# Altium schematic sheet 09 ELRS3.0 V1.1.SchDoc
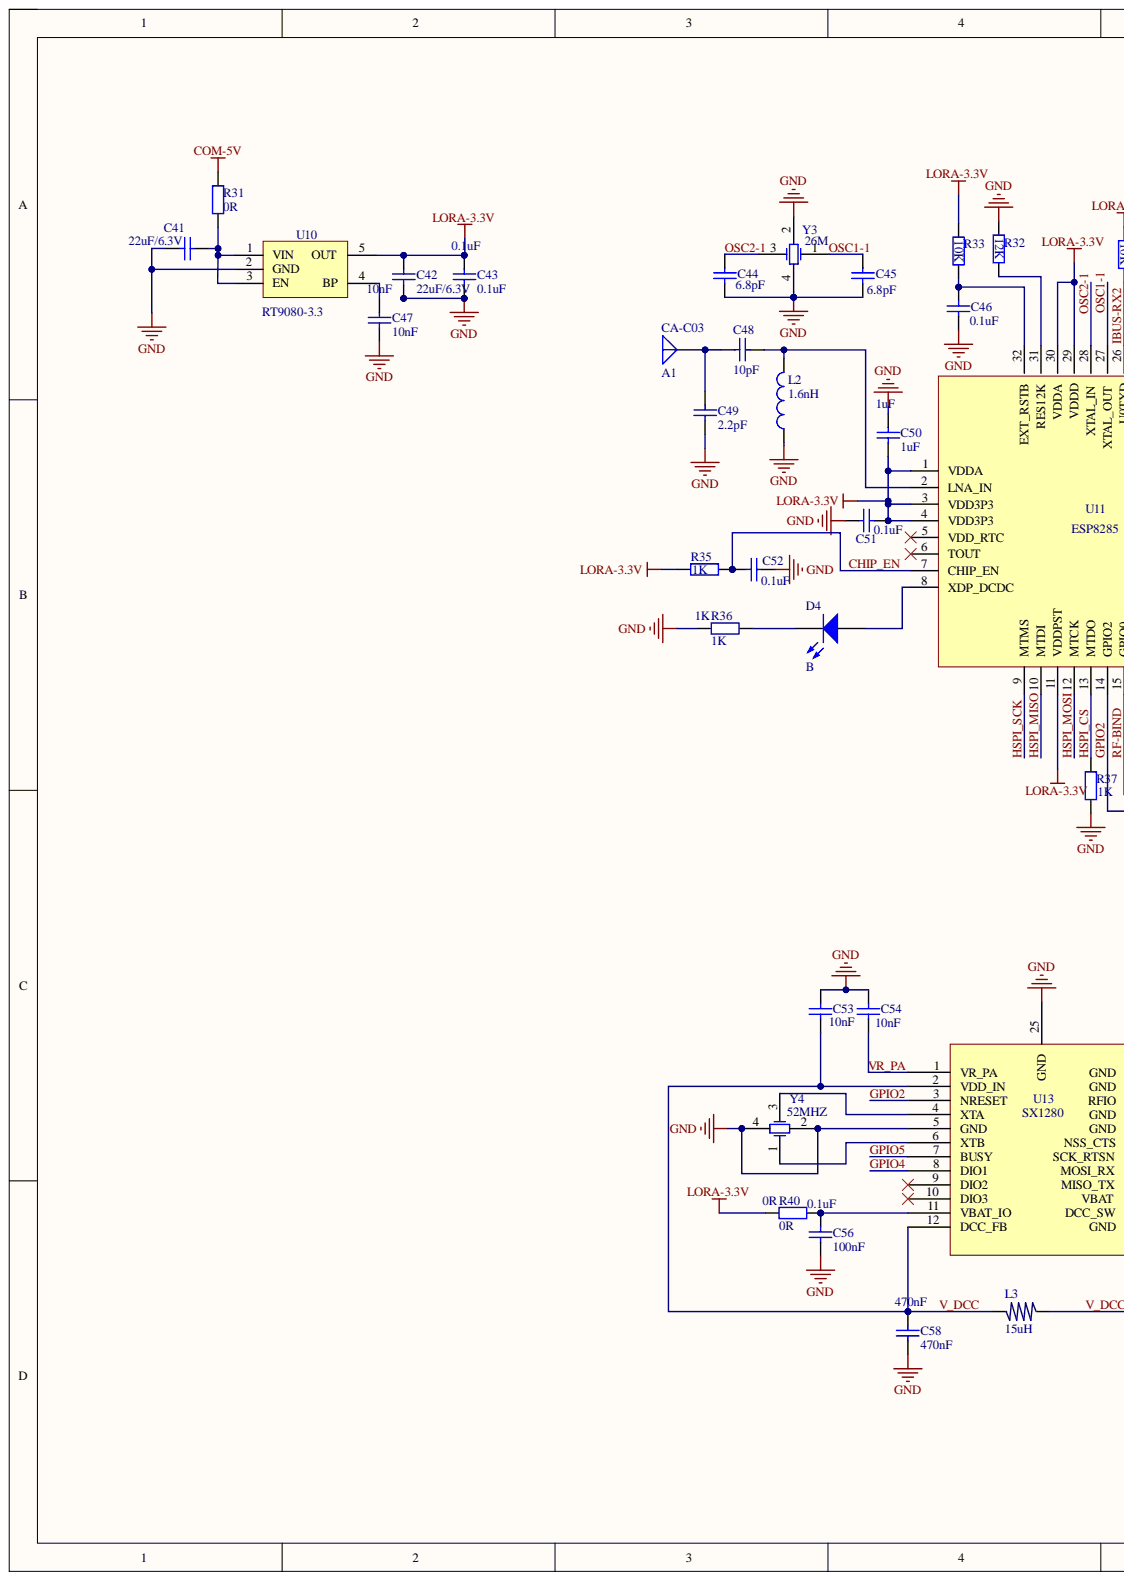
[diagram p0: 09 ELRS3.0 V1.1.SchDoc, left]
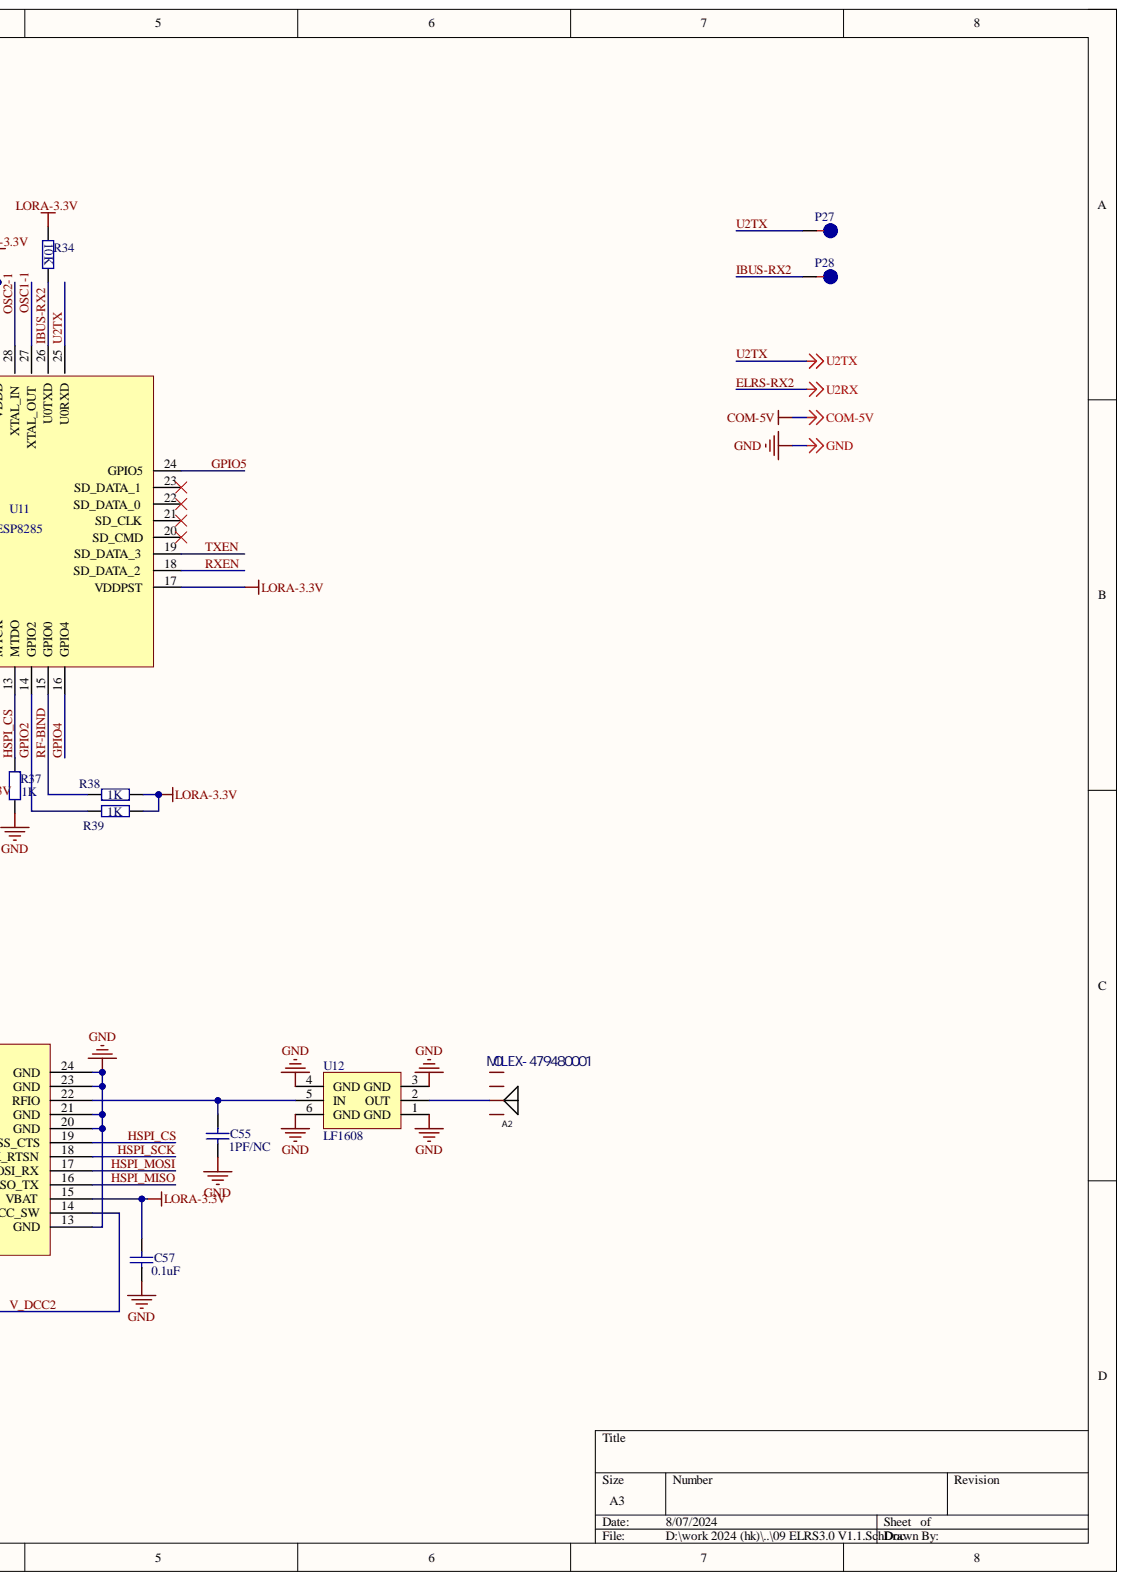
[diagram p1: 09 ELRS3.0 V1.1.SchDoc, right]

## Parts
A1  CA-C03  [ANT-SMD-2.4G]
A2  MOLEX-479480001  [ANT-MOLEX]
C41  22uF/6.3V  [C0603]
C42  22uF/6.3V  [C0603]
C43  0.1uF  [C0201]
C44  6.8pF  [C0201]
C45  6.8pF  [C0201]
C46  0.1uF  [C0201]
C47  10nF  [C0201]
C48  10pF  [C0201]
C49  2.2pF  [C0201]
C50  1uF  [C0201]
C51  0.1uF  [C0201]
C52  0.1uF  [C0201]
C53  10nF  [C0201]
C54  10nF  [C0201]
C55  1PF/NC  [C0201]
C56  0.1uF  value 100nF  [C0201]
C57  0.1uF  [C0201]
C58  470nF  [C0201]
D4  B  [LED0402B]
L2  1.6nH  [R0201]
L3  15uH  [R0805]
P27  (no value)  [TP08]
P28  (no value)  [TP08]
R31  0R  [R0603]
R32  12K  [R0201]
R33  10K  [R0201]
R34  10K  [R0201]
R35  1K  [R0201]
R36  1K  [R0201]
R37  1K  [R0201]
R38  1K  [R0201]
R39  1K  [R0201]
R40  0R  [R0201]
U10  RT9080-3.3  [RT9193]
U11  ESP8285  [ESP8285]
U12  LF1608  [RF]
U13  SX1280  [SX1280]
Y3  26M  [XTAL-27M]
Y4  52MHZ  [XTAL-27M]

## Nets
COM-5V: R31.1
GND: C41.2 C42.2 C43.2 C44.2 C45.2 C46.1 C47.2 C49.2 C50.1 C51.1 C52.1 C53.2 C54.2 C55.1 C56.1 C57.1 C58.1 L2.1 R32.1 R36.2 R37.2 U10.2(GND) U12.1(GND) U12.3(GND) U12.4(GND) U12.6(GND) U13.5(GND) U13.13(GND) U13.20(GND) U13.21(GND) U13.23(GND) U13.24(GND) U13.25(GND) Y3.2 Y3.4 Y4.2 Y4.4
LORA-3.3V: C42.1 C43.1 C50.2 C51.2 C57.2 R33.1 R34.2 R35.2 R38.2 R39.2 R40.2 U10.5(OUT) U11.1(VDDA) U11.3(VDD3P3) U11.4(VDD3P3) U11.11(VDDPST) U11.17(VDDPST) U11.29(VDDD) U11.30(VDDA) U13.15(VBAT)
CHIP_EN: C52.2 R35.1 U11.7(CHIP_EN)
GPIO2: R39.1 U11.14(GPIO2) U13.3(NRESET)
GPIO4: U11.16(GPIO4) U13.8(DIO1)
GPIO5: U11.24(GPIO5) U13.7(BUSY)
HSPI_CS: R37.1 U11.13(MTDO) U13.19(NSS_CTS)
HSPI_MISO: U11.10(MTDI) U13.16(MISO_TX)
HSPI_MOSI: U11.12(MTCK) U13.17(MOSI_RX)
HSPI_SCK: U11.9(MTMS) U13.18(SCK_RTSN)
IBUS-RX2: P28.1 R34.1 U11.26(U0TXD)
OSC1-1: C45.1 U11.27(XTAL_OUT) Y3.1
OSC2-1: C44.1 U11.28(XTAL_IN) Y3.3
RF-BIND: R38.1 U11.15(GPIO0)
RXEN: U11.18(SD_DATA_2)
TXEN: U11.19(SD_DATA_3)
U2TX: P27.1 U11.25(U0RXD)
V_DCC: C53.1 C58.2 L3.2 U13.2(VDD_IN) U13.12(DCC_FB)
V_DCC2: L3.1 U13.14(DCC_SW)
VR_PA: C54.1 U13.1(VR_PA)
NetA1_1: A1.1 C48.1 C49.1
NetA2_3: A2.3 U12.2(OUT)
NetC41_1: C41.1 R31.2 U10.1(VIN) U10.3(EN)
NetC46_2: C46.2 R33.2 U11.32(EXT_RSTB)
NetC47_1: C47.1 U10.4(BP)
NetC48_2: C48.2 L2.2 U11.2(LNA_IN)
NetC55_2: C55.2 U12.5(IN) U13.22(RFIO)
NetC56_2: C56.2 R40.1 U13.11(VBAT_IO)
NetD4_1: D4.1 U11.8(XDP_DCDC)
NetD4_2: D4.2 R36.1
NetR32_2: R32.2 U11.31(RES12K)
NetU13_4: U13.4(XTA) Y4.3
NetU13_6: U13.6(XTB) Y4.1
ELRS-RX2:

Not connected: A2 1 2 4; U11 5(VDD_RTC) 6(TOUT) 20(SD_CMD) 21(SD_CLK) 22(SD_DATA_0) 23(SD_DATA_1); U13 9(DIO2) 10(DIO3)

## Library data of the parts
A1 = Antenna: Generic Antenna; Component Kind: Standard; LatestRevisionDate: 17-Jul-2002; LatestRevisionNote: Re-released for DXP Platform.; Library Name: Miscellaneous Devices.IntLib; PackageReference: PIN1; Published: 24-Mar-1999; Publisher: Altium Limited
A2 = ANT-MOLEX: Manufacturer: MOLEX; Add into BOM: yes; Antenna Type: 2.4G天线; Symbol: 47948-0001; Bandwidth: -; Central Frequency: -; Component Kind: Standard; Convert to PCB: yes; Datasheet: https://atta.szlcsc.com/upload/public/pdf/source/20180207/C152351_15179949407931359155.pdf; Device: 479480001; Impedance: -; JLCPCB Part Class: Extended Part; LCSC Part Name: 2.4G天线; Library Name: AT32F421K8U7temp V1.0.SCHLIB; Manufacturer Part: 479480001; Operating Temperature: -; Peak Gain: -; Polarization Type: -; Power capacity: -; Supplier: LCSC; Supplier Footprint: SMD,3.2x4mm; Supplier Part: C152351; VSWR: -
C41 C42 = C0603: Capacitor; Value: 22uF; Component Kind: Standard; Library Name: Integrated_Library_gr.IntLib
C43-C45 C47 C49 C52 = C0201: Capacitor; Component Kind: Standard; Library Name: Integrated_Library_gr.IntLib
  C43 C52: Value: 0.1uF
  C44 C45: Value: 6.8pF
  C47: Value: 10nF
  C49: Value: 2.2pF
C46 C50 C51 C53 C54 C56 C58 = C0402: Capacitor; Component Kind: Standard; Library Name: Integrated_Library_gr.IntLib
  C46 C51: Value: 0.1uF
  C50: Value: 1uF
  C53 C54: Value: 10nF
  C58: Value: 470nF
C48 = C0201: Capacitor; Value: 0.1uF; Component Kind: Standard; Library Name: Integrated_Library_gr.IntLib
C55 = C0402: Capacitor; Value: 1pF; Component Kind: Standard; Library Name: Integrated_Library_gr.IntLib
C57 = C0402: Capacitor; Value: 100nF; Component Kind: Standard; Library Name: Integrated_Library_gr.IntLib
D4 = LED0603B: LED; Component Kind: Standard; Library Name: Integrated_Library_gr.IntLib
L2 = Inductor: Inductor SMD; Code_IEC: 1005; Code_JEITA: 0402; Component Kind: Standard; LatestRevisionDate: 05-oct-2007  -  Semtech; LatestRevisionNote: Re-released for DXP Platform.; Library Name: SX1276_e269v01a.SchLib; Published: 8-Jun-2000; Signal Integrity: Inductor; Simulation: INDUCTOR; Value: 1.6nH; Publisher: Altium Limited
L3 = L: Component Kind: Standard; Library Name: Integrated_Library_gr.IntLib
P27 P28 = TP: Component Kind: Standard
R31-R33 R35-R40 = R0201: Resistor; Component Kind: Standard; Library Name: Integrated_Library_gr.IntLib
  R31 R40: Value: 0R
  R32: Value: 12K
  R33: Value: 10K
  R35-R39: Value: 1K
R34 = R0201: Resistor; Value: 1K; Component Kind: Standard; Library Name: Integrated_Library_gr.IntLib
U10 = RT9193: IC; Component Kind: Standard; Library Name: Integrated_Library_gr.IntLib
U12 = DPX165950DT: Component Kind: Standard; Library Name: Integrated_Library_gr.IntLib
Y3 Y4 = XTAL-27: OSC; Component Kind: Standard; Library Name: Integrated_Library_gr.IntLib
U11 = ESP8285: Component Kind: Standard; Library Name: Integrated_Library_gr.IntLib
U13 = SX1280: Component Kind: Standard; Library Name: Integrated_Library_gr.IntLib
